# Altium schematic sheet FETs.SchDoc
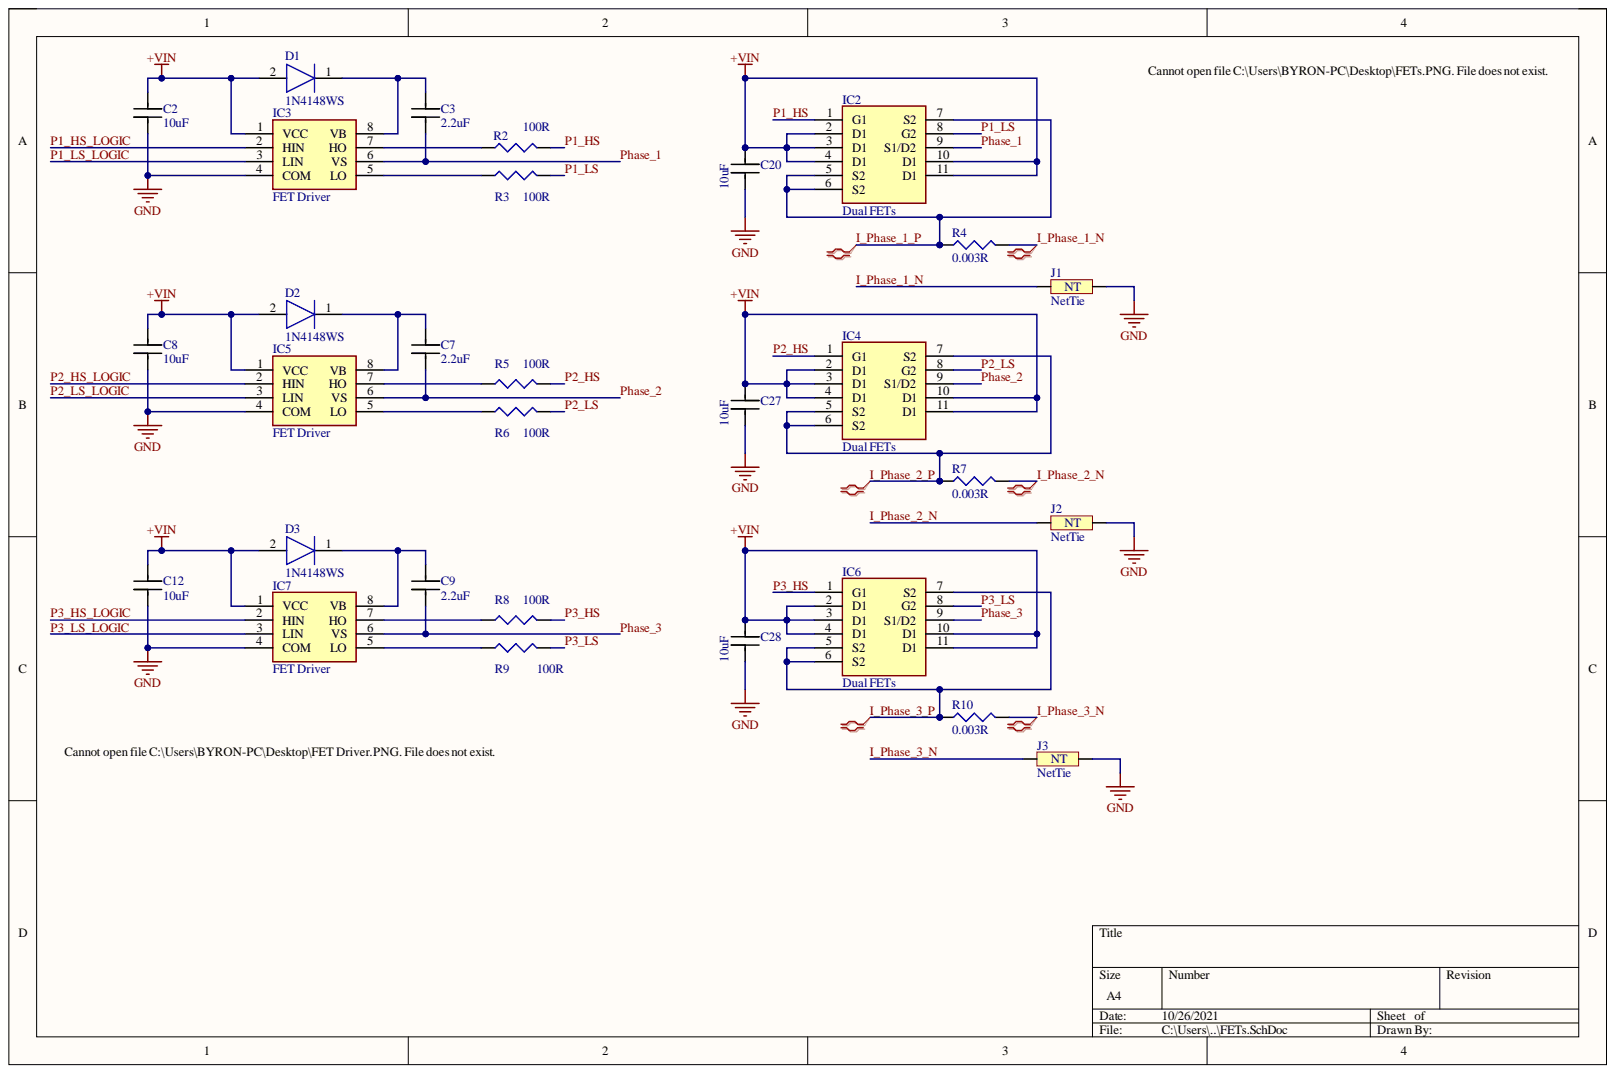
[diagram p0: FETs.SchDoc]

## Parts
C2  10uF  [Capacitor 0805]
C3  2.2uF
C7  2.2uF
C8  10uF  [Capacitor 0805]
C9  2.2uF
C12  10uF  [Capacitor 0805]
C20  10uF  [Capacitor 0805]
C27  10uF  [Capacitor 0805]
C28  10uF  [Capacitor 0805]
D1  1N4148WS  [SODFL2512X100N]
D2  1N4148WS  [SODFL2512X100N]
D3  1N4148WS  [SODFL2512X100N]
IC2  Dual FETs  [BSC0921NDIATMA1]
IC3  FET Driver  [SOIC127P600X175-8N]
IC4  Dual FETs  [BSC0921NDIATMA1]
IC5  FET Driver  [SOIC127P600X175-8N]
IC6  Dual FETs  [BSC0921NDIATMA1]
IC7  FET Driver  [SOIC127P600X175-8N]
J1  NetTie  [NetTie]
J2  NetTie  [NetTie]
J3  NetTie  [NetTie]
R2  100R  [0402_RES_RESC1005X40N]
R3  100R  [0402_RES_RESC1005X40N]
R4  0.003R  [RESISTOR 2512]
R5  100R  [0402_RES_RESC1005X40N]
R6  100R  [0402_RES_RESC1005X40N]
R7  0.003R  [RESISTOR 2512]
R8  100R  [0402_RES_RESC1005X40N]
R9  100R  [0402_RES_RESC1005X40N]
R10  0.003R  [RESISTOR 2512]

## Nets
+VIN: C2.1 C8.1 C12.1 C20.1 C27.1 C28.1 D1.2 D2.2 D3.2 IC2.2(D1) IC2.3(D1) IC2.4(D1) IC2.10(D1) IC2.11(D1) IC3.1(VCC) IC4.2(D1) IC4.3(D1) IC4.4(D1) IC4.10(D1) IC4.11(D1) IC5.1(VCC) IC6.2(D1) IC6.3(D1) IC6.4(D1) IC6.10(D1) IC6.11(D1) IC7.1(VCC)
GND: C2.2 C8.2 C12.2 C20.2 C27.2 C28.2 IC3.4(COM) IC5.4(COM) IC7.4(COM) J1.2 J2.2 J3.2
I_Phase_1_N: J1.1 R4.2
I_Phase_1_P: IC2.5(S2) IC2.6(S2) IC2.7(S2) R4.1
I_Phase_2_N: J2.1 R7.2
I_Phase_2_P: IC4.5(S2) IC4.6(S2) IC4.7(S2) R7.1
I_Phase_3_N: J3.1 R10.2
I_Phase_3_P: IC6.5(S2) IC6.6(S2) IC6.7(S2) R10.1
P1_HS: IC2.1(G1) R2.2
P1_HS_LOGIC: IC3.2(HIN)
P1_LS: IC2.8(G2) R3.2
P1_LS_LOGIC: IC3.3(LIN)
P2_HS: IC4.1(G1) R5.2
P2_HS_LOGIC: IC5.2(HIN)
P2_LS: IC4.8(G2) R6.2
P2_LS_LOGIC: IC5.3(LIN)
P3_HS: IC6.1(G1) R8.2
P3_HS_LOGIC: IC7.2(HIN)
P3_LS: IC6.8(G2) R9.2
P3_LS_LOGIC: IC7.3(LIN)
Phase_1: C3.1 IC2.9(S1/D2) IC3.6(VS)
Phase_2: C7.1 IC4.9(S1/D2) IC5.6(VS)
Phase_3: C9.1 IC6.9(S1/D2) IC7.6(VS)
NetC3_2: C3.2 D1.1 IC3.8(VB)
NetC7_2: C7.2 D2.1 IC5.8(VB)
NetC9_2: C9.2 D3.1 IC7.8(VB)
NetIC3_5: IC3.5(LO) R3.1
NetIC3_7: IC3.7(HO) R2.1
NetIC5_5: IC5.5(LO) R6.1
NetIC5_7: IC5.7(HO) R5.1
NetIC7_5: IC7.5(LO) R9.1
NetIC7_7: IC7.7(HO) R8.1

## Library data of the parts
C2 C8 C12 C20 C27 C28 = GMK212BBJ106KG-T: 10uF 35VDC 0805; Manufacturer 1: Taiyo Yuden; Tolerance: 10%; Voltage Rating: 35V; Capacitance: 10uF; Case Code (Imperial): 0805; Case Code (Metric): 2012; Case/Package: 0805; Component Kind: Standard; ComponentLink1Description: http://ds.yuden.co.jp/TYCOMPAS/ut/detail.do?productNo=GMK212BBJ106KG-T&fileName=GMK212BBJ106KG-T_SS&mode=specSheetDownload&src-supplier=Digi-Key; ComponentLink1URL: http://ds.yuden.co.jp/TYCOMPAS/ut/detail.do?productNo=GMK212BBJ106KG-T&fileName=GMK212BBJ106KG-T_SS&mode=specSheetDownload&src-supplier=Digi-Key; ComponentLink2Description: http://www.farnell.com/datasheets/2819844.pdf?src-supplier=Newark; ComponentLink2URL: http://www.farnell.com/datasheets/2819844.pdf?src-supplier=Newark; ComponentLink3Description: http://www.yuden.co.jp/productdata/catalog/mlcc06_e.pdf?src-supplier=Digi-Key; ComponentLink3URL: http://www.yuden.co.jp/productdata/catalog/mlcc06_e.pdf?src-supplier=Digi-Key; Lead Free: Lead Free; Library Name: Schlib1.SchLib; Manufacturer Part Number 1: GMK212BBJ106KG-T; Max Operating Temperature: 85°C; Min Operating Temperature: -55°C; Mount: Surface Mount; Packaging: Cut Tape; Pricing 1: 1=0,78000, 10=0,55200, 100=0,36380, 500=0,26946, 1000=0,22904 (USD); RoHS: No; Stock 1: 92799; Supplier 1: Digi-Key; Supplier Part Number 1: 587-4893-1-ND
C3 C7 C9 = C1005X5R1V225K050BC: TDK - C1005X5R1V225K050BC - Keramikvielschichtkondensator, SMD, 2.2 µF, 35 V, 0402 [Metrisch 1005], ± 10%, X5R, C Series TDK; Dielectric Material: Ceramic; Voltage Rating: 35V; Capacitance: 2.2uF; Case Code (Imperial): 0402; Case Code (Metric): 1005; Case/Package: 0402; Component Kind: Standard; ComponentLink1Description: https://datasheet.ciiva.com/24218/mlcc-commercial-general-en-24218938.pdf?src-supplier=Mouser; ComponentLink1URL: https://datasheet.ciiva.com/24218/mlcc-commercial-general-en-24218938.pdf?src-supplier=Mouser; Composition: Ceramic; Depth: 0.5mm; Dielectric: X5R; Height: 0.5mm; Lead Free: Lead Free; Length: 1mm; Library Name: Schlib1.SchLib; Manufacturer 1: TDK; Manufacturer Part Number 1: C1005X5R1V225K050BC; Max Operating Temperature: 85°C; Min Operating Temperature: -55°C; Mount: Surface Mount; Package Quantity: 1; Packaging: Tape and Reel; Pricing 1: 1=0.36000, 10=0.25300, 100=0.15180, 500=0.11386, 1000=0.09614, 2500=0.09108, 5000=0.08602 (USD); REACH SVHC: No SVHC; RoHS: Yes; Stock 1: 253758; Supplier 1: Digi-Key; Termination: SMD/SMT; Thickness: 0.022inch; Tolerance: 10%; Voltage Rating (DC): 35V; Weight: 2.3E-05oz; Width: 0.5mm
D1-D3 = 1N4148WS: Diode; Manufacturer_Name: Fairchild Semiconductor; Component Kind: Standard; Datasheet Link: http://docs-emea.rs-online.com/webdocs/10e1/0900766b810e1cd3.pdf; Height: 1mm; Library Name: Schlib1.SchLib; Manufacturer_Part_Number: 1N4148WS; Mouser Part Number: 512-1N4148WS; Mouser Price/Stock: https://www.mouser.com/Search/Refine.aspx?Keyword=512-1N4148WS
IC2 IC4 IC6 = BSC0921NDIATMA1: MOSFET (N-Channel); Manufacturer_Name: Infineon; Component Kind: Standard; Datasheet Link: https://componentsearchengine.com/Datasheets/2/BSC0921NDIATMA1.pdf; Height: 1.15mm; Library Name: Schlib1.SchLib; Manufacturer_Part_Number: BSC0921NDIATMA1; Mouser Part Number: 726-SP000934748; Mouser Price/Stock: https://www.mouser.com/Search/Refine.aspx?Keyword=726-SP000934748; RS Part Number: 1339832; RS Price/Stock: https://uk.rs-online.com/web/p/products/1339832
IC3 IC5 IC7 = IR2301SPBF: Integrated Circuit; Manufacturer_Name: Infineon; Allied_Number: 70018742; Allied Price/Stock: https://www.alliedelec.com/infineon-ir2301spbf/70018742/; Component Kind: Standard; Datasheet Link: https://www.infineon.com/dgdl/ir2301.pdf?fileId=5546d462533600a4015355c97bb216dc; Height: 1.75mm; Library Name: Schlib1.SchLib; Manufacturer_Part_Number: IR2301SPBF; Mouser Part Number: 942-IR2301SPBF; Mouser Price/Stock: https://www.mouser.com/Search/Refine.aspx?Keyword=942-IR2301SPBF; RS Part Number: 9074842P; RS Price/Stock: http://uk.rs-online.com/web/p/products/9074842P
R2 R3 R5 R6 R8 R9 = RC0402JR-07100RL: RES SMD 100 OHM 5% 1/16W 0402; Manufacturer 1: Yageo; Max Power Dissipation: 63mW; Temperature Coefficient: 100ppm/°C; Voltage Rating: 50V; Case Code (Imperial): 0402; Case Code (Metric): 1005; Case/Package: 0402; Component Kind: Standard; ComponentLink1Description: https://datasheet.ciiva.com/2452/pyu-r-int-thick-7-2452619.pdf?src-supplier=Digi-Key; ComponentLink1URL: https://datasheet.ciiva.com/2452/pyu-r-int-thick-7-2452619.pdf?src-supplier=Digi-Key; ComponentLink2Description: https://datasheet.ciiva.com/16740/pyu-rc0402-51-rohs-l-6-r-16740924.pdf?src-supplier=Future+Electronics; ComponentLink2URL: https://datasheet.ciiva.com/16740/pyu-rc0402-51-rohs-l-6-r-16740924.pdf?src-supplier=Future+Electronics; ComponentLink3Description: https://datasheet.ciiva.com/12577/pyu-rc0402-51-rohs-l-6-r-12577725.pdf?src-supplier=Mouser; ComponentLink3URL: https://datasheet.ciiva.com/12577/pyu-rc0402-51-rohs-l-6-r-12577725.pdf?src-supplier=Mouser; ComponentLink4Description: https://datasheet.ciiva.com/2452/pyu-r-int-thick-7-2452617.pdf?src-supplier=Digi-Key; ComponentLink4URL: https://datasheet.ciiva.com/2452/pyu-r-int-thick-7-2452617.pdf?src-supplier=Digi-Key; ComponentLink5Description: https://datasheet.ciiva.com/46394/1018594-46394943.pdf?src-supplier=Element14; ComponentLink5URL: https://datasheet.ciiva.com/46394/1018594-46394943.pdf?src-supplier=Element14; ComponentLink6Description: https://datasheet.ciiva.com/7850/1018594-7850134.pdf?src-supplier=Element14; ComponentLink6URL: https://datasheet.ciiva.com/7850/1018594-7850134.pdf?src-supplier=Element14; ComponentLink7Description: https://datasheet.ciiva.com/7850/1018594-7850135.pdf?src-supplier=Element14; ComponentLink7URL: https://datasheet.ciiva.com/7850/1018594-7850135.pdf?src-supplier=Element14; ComponentLink8Description: https://datasheet.ciiva.com/2452/pyu-r-int-thick-7-2452618.pdf?src-supplier=Digi-Key; ComponentLink8URL: https://datasheet.ciiva.com/2452/pyu-r-int-thick-7-2452618.pdf?src-supplier=Digi-Key; Composition: Thick Film; Depth: 0.5mm; Features: Moisture Resistant; Height: 0.016inch; Lead Free: Lead Free; Length: 1mm; Library Name: Schlib1.SchLib; Manufacturer Part Number 1: RC0402JR-07100RL; Max Operating Temperature: 155°C; Military Standard: Not; Min Operating Temperature: -55°C; Number of Terminations: 2; Package Quantity: 1; Packaging: Tape and Reel; Power Rating: 63mW; Pricing 1: 1=0,10000, 10=0,01300, 100=0,00530, 1000=0,00236, 2500=0,00205, 5000=0,00169 (USD); REACH SVHC: No SVHC; Resistance: 100R; RoHS: Yes; Stock 1: 5850295; Supplier 1: Digi-Key; Supplier Part Number 1: 311-100JRCT-ND; Termination: SMD/SMT; Tolerance: 5%; Weight: 2.3E-05oz; Width: 0.02inch
R4 R7 R10 = CRE2512-FZ-R003E-2: BOURNS   CRE2512-FZ-R003E-2   SMD Current Sense Resistor, AEC-Q200 CRE Series, 0.003 ohm, 2 W,  1%, 2512 [6432 Metric]; Max Power Dissipation: 2W; Resistance: 3mR; Temperature Coefficient: 75ppm/°C; Case Code (Imperial): 2512; Case Code (Metric): 6432; Case/Package: 2512; Component Kind: Standard; ComponentLink1Description: https://datasheet.ciiva.com/46424/1838634-46424790.pdf?src-supplier=Element14; ComponentLink1URL: https://datasheet.ciiva.com/46424/1838634-46424790.pdf?src-supplier=Element14; ComponentLink2Description: https://datasheet.ciiva.com/48532/cre-48532220.pdf?src-supplier=Avnet; ComponentLink2URL: https://datasheet.ciiva.com/48532/cre-48532220.pdf?src-supplier=Avnet; ComponentLink3Description: https://datasheet.ciiva.com/46424/1838634-46424791.pdf?src-supplier=Element14; ComponentLink3URL: https://datasheet.ciiva.com/46424/1838634-46424791.pdf?src-supplier=Element14; Composition: Metal Film,Thick Film,Metal Alloy; Contact Plating: Tin; Depth: 3.35mm; Features: Moisture Resistant,Automotive AEC-Q200; Height: 0.035inch; Length: 0.254inch; Library Name: Schlib1.SchLib; Manufacturer 1: Bourns; Manufacturer Part Number 1: CRE2512-FZ-R003E-2; Max Operating Temperature: 170°C; Min Operating Temperature: -55°C; Mount: Surface Mount; Number of Terminations: 2; Packaging: Cut Tape; Power Rating: 2W; Pricing 1: 1=0.86000, 10=0.74300, 25=0.65320, 50=0.59680, 100=0.51800, 250=0.45044, 500=0.37388, 1000=0.30405 (USD); REACH SVHC: No SVHC; RoHS: Yes; Stock 1: 16983; Supplier 1: Digi-Key; Termination: Solder; Tolerance: 1%; Width: 0.132inch
J1-J3 = NetTie: Component Kind: Net Tie; Library Name: Schlib1.SchLib
